# Altium schematic sheet BLE_NRF52832.SchDoc(BLE_NRF52832)
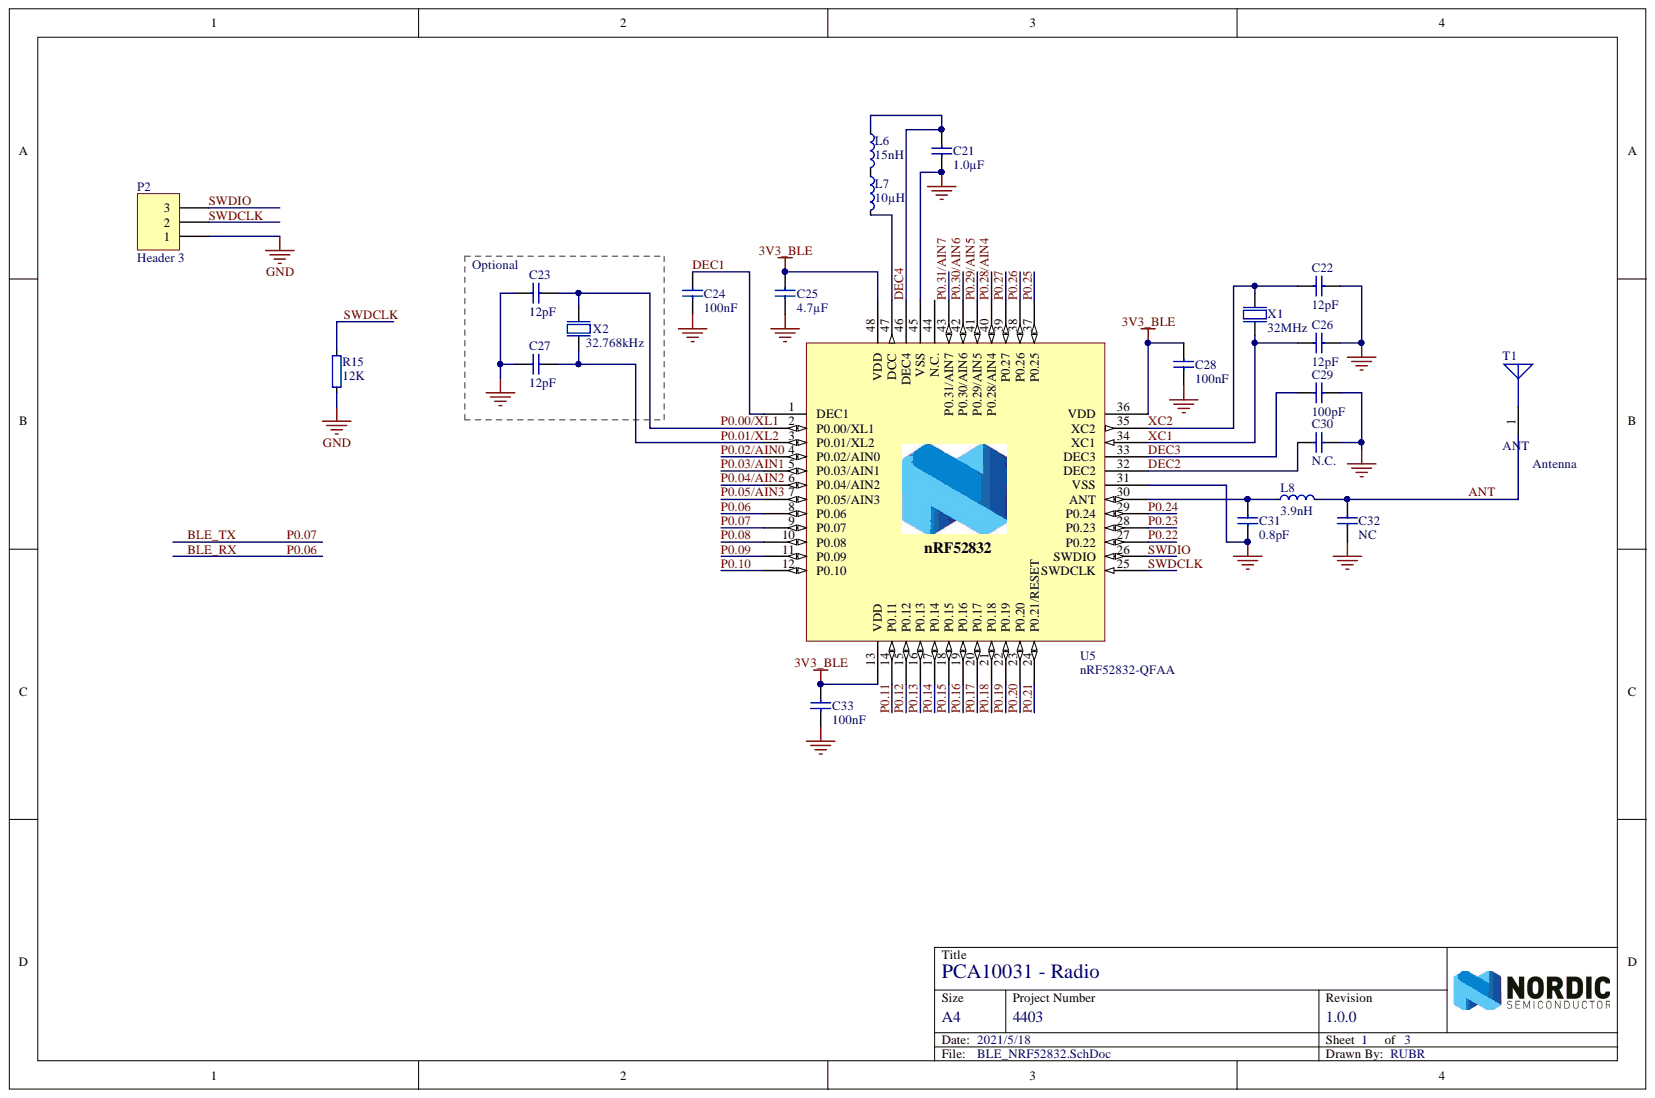
[diagram p0: BLE_NRF52832.SchDoc]

## Parts
C21  1.0µF  [0402C]
C22  12pF  [0402C]
C23  12pF  [0402C]
C24  100nF  [0402C]
C25  4.7µF  [C0603]
C26  12pF  [0402C]
C27  12pF  [0402C]
C28  100nF  [0402C]
C29  100pF  [0402C]
C30  N.C.  [0402C]
C31  0.8pF  [0402C]
C32  NC  [0402C]
C33  100nF  [0402C]
L6  15nH  [0402L]
L7  10µH  [0402L]
L8  3.9nH  [0402L]
P2  Header 3  [HDR1X3]
R15  12K  [0402R]
T1  ANT
U5  nRF52832-QFAA  [QFN40P600X600X90-48N]
X1  32MHz  [BT-XTAL_2016]
X2  32.768kHz  [X_2012]

## Nets
3V3_BLE: C25.1 C28.1 C33.1 U5.13(VDD) U5.36(VDD) U5.48(VDD)
GND: C21.1 C22.2 C23.2 C24.2 C25.2 C26.2 C27.2 C28.2 C29.2 C30.1 C31.1 C32.1 C33.2 P2.1 R15.1 U5.31(VSS) U5.45(VSS) U5.49 X1.2 X1.4
ANT: C32.2 L8.2 T1.1
BLE_RX: U5.8(P0.06)
BLE_TX: U5.9(P0.07)
DEC1: C24.1 U5.1(DEC1)
DEC2: C30.2 U5.32(DEC2)
DEC3: C29.1 U5.33(DEC3)
DEC4: C21.2 L6.1 U5.46(DEC4)
P0.00/XL1: C23.1 U5.2(P0.00/XL1) X2.1
P0.01/XL2: C27.1 U5.3(P0.01/XL2) X2.2
P0.02/AIN0: U5.4(P0.02/AIN0)
P0.03/AIN1: U5.5(P0.03/AIN1)
P0.04/AIN2: U5.6(P0.04/AIN2)
P0.05/AIN3: U5.7(P0.05/AIN3)
P0.08: U5.10(P0.08)
P0.09: U5.11(P0.09)
P0.10: U5.12(P0.10)
P0.11: U5.14(P0.11)
P0.12: U5.15(P0.12)
P0.13: U5.16(P0.13)
P0.14: U5.17(P0.14)
P0.15: U5.18(P0.15)
P0.16: U5.19(P0.16)
P0.17: U5.20(P0.17)
P0.18: U5.21(P0.18)
P0.19: U5.22(P0.19)
P0.20: U5.23(P0.20)
P0.21: U5.24(P0.21/RESET)
P0.22: U5.27(P0.22)
P0.23: U5.28(P0.23)
P0.24: U5.29(P0.24)
P0.25: U5.37(P0.25)
P0.26: U5.38(P0.26)
P0.27: U5.39(P0.27)
P0.28/AIN4: U5.40(P0.28/AIN4)
P0.29/AIN5: U5.41(P0.29/AIN5)
P0.30/AIN6: U5.42(P0.30/AIN6)
P0.31/AIN7: U5.43(P0.31/AIN7)
SWDCLK: P2.2 R15.2 U5.25(SWDCLK)
SWDIO: P2.3 U5.26(SWDIO)
XC1: C26.1 U5.34(XC1) X1.1
XC2: C22.1 U5.35(XC2) X1.3
NetC31_2: C31.2 L8.1 U5.30(ANT)
NetL6_2: L6.2 L7.1
NetL7_2: L7.2 U5.47(DCC)

Not connected: U5 44(N.C.)

## Library data of the parts
C21 = C0603-1µ0: Capacitor, X7R, ±10%; Artikkelnr Noca: 20039335; Artikkelnr Simpro: N.A.; Database Table Name: Capacitors$; Note: 16V; Component Kind: Standard; Footprint Doc: 0603; LatestRevisionDate: 2013-06-05; Library Name: Nordic Passive DB.DbLib; Part Number: C0603-1µ0; Pin Count: 2; Published: 2009-05-08; Value: 1.0µF; Publisher: Nordic Semiconductor
C22 C23 C26 C27 = C0402-12p: Capacitor, NP0, ±2%; Artikkelnr Noca: 20029159; Artikkelnr Simpro: N.A.; Database Table Name: Capacitors$; Note: 50V; Component Kind: Standard; Footprint Doc: 0402; LatestRevisionDate: 2011-05-11; Library Name: Nordic Passive DB.DbLib; Part Number: C0402-12p; Pin Count: 2; Published: 2009-05-08; Value: 12pF; Publisher: Nordic Semiconductor
C24 C28 C33 = C0402-100n: Capacitor, X7R, ±10%; Artikkelnr Noca: 20039200; Artikkelnr Simpro: N.A.; Database Table Name: Capacitors$; Note: 16V; Component Kind: Standard; Footprint Doc: 0402; LatestRevisionDate: 2009-11-23; Library Name: Nordic Passive DB.DbLib; Part Number: C0402-100n; Pin Count: 2; Published: 2009-05-08; Value: 100nF; Publisher: Nordic Semiconductor
C25 = C0603-4µ7: Capacitor, X5R, ±10%; Artikkelnr Noca: 20069060; Artikkelnr Simpro: N.A.; Database Table Name: Capacitors$; Note: 6.3V; Component Kind: Standard; Footprint Doc: 0603; LatestRevisionDate: 2009-11-23; Library Name: Nordic Passive DB.DbLib; Part Number: C0603-4µ7; Pin Count: 2; Published: 2009-05-08; Value: 4.7µF; Publisher: Nordic Semiconductor
C29 = C0402-100p: Capacitor, NP0, ±5%; Artikkelnr Noca: 20029135; Artikkelnr Simpro: N.A.; Database Table Name: Capacitors$; Note: 50V; Component Kind: Standard; Footprint Doc: 0402; LatestRevisionDate: 2009-05-08; Library Name: Nordic Passive DB.DbLib; Part Number: C0402-100p; Pin Count: 2; Published: 2009-05-08; Value: 100pF; Publisher: Nordic Semiconductor
C30 = C0402-N.C.: Not mounted; Artikkelnr Noca: N.A.; Database Table Name: Capacitors$; Note: Chip Cap; Artikkelnr Simpro: N.A.; Component Kind: Standard; Footprint Doc: 0402; LatestRevisionDate: 2009-05-08; Library Name: Nordic Passive DB.DbLib; Part Number: C0402-N.C.; Pin Count: 2; Published: 2009-05-08; Value: N.C.; Publisher: Nordic Semiconductor
C31 = C0402-0.8p: Capacitor, NP0, ±5%; Artikkelnr Noca: 20029147; Artikkelnr Simpro: N.A.; Database Table Name: Capacitors$; Note: 50V; Component Kind: Standard; Footprint Doc: 0402; LatestRevisionDate: 2012-11-21; Library Name: Nordic Passive DB.DbLib; Part Number: C0402-0.8p; Pin Count: 2; Published: 2009-05-08; Value: 0.8pF; Publisher: Nordic Semiconductor
C32 = C0402-0.8p: Capacitor, NP0, ±5%; Artikkelnr Noca: 20029147; Artikkelnr Simpro: N.A.; Database Table Name: Capacitors$; Note: 50V; Value: 0.8pF; Component Kind: Standard; Footprint Doc: 0402; LatestRevisionDate: 2012-11-21; Library Name: Nordic Passive DB.DbLib; Part Number: C0402-0.8p; Pin Count: 2; Published: 2009-05-08; Publisher: Nordic Semiconductor
L6 = L0402-15n: High frequency chip inductor ±10%; 2nd source part number: 658-1328; Manufacturer: Taiyo Yuden; Manufacturer Part Number: HK100515NJ-T; Artikkelnr Noca: 33029323; Artikkelnr Simpro: N.A.; Database Table Name: Inductors$; 2nd source Supplier: Farnell; Component Kind: Standard; Datasheet: http://www.yuden.co.jp/productdata/catalog/en/mlci04_e.pdf; Footprint Doc: 0402; LatestRevisionDate: 2012-09-19; Library Name: Nordic Passive DB.DbLib; Note: High frequency chip inductor ±10%; Part Number: L0402-15n; Pin Count: 2; Published: 2010-07-12; Supplier: Digi-Key; Supplier part number: 587-1521-1-ND; Value: 15nH; Publisher: Nordic Semiconductor
L7 = L0603-10µ: Chip inductor, IDC,min = 50 mA, ±20%; 2nd source part number: 178-6105; Manufacturer: Taiyo Yuden; Manufacturer Part Number: LBMF1608T100K; Artikkelnr Noca: 33029314; Artikkelnr Simpro: N.A.; Database Table Name: Inductors$; Note: LBMF1608T100K 10µH 80mA 0603; 2nd source Supplier: Farnell; Component Kind: Standard; Datasheet: http://www.yuden.co.jp/ut/product/pdf/elb2_e.pdf; Footprint Doc: 0603; LatestRevisionDate: 2012-09-19; Library Name: Nordic Passive DB.DbLib; Part Number: L0603-10µ; Pin Count: 2; Published: 2010-02-24; Supplier: Digi-Key; Supplier part number: 587-1714-1-ND; Value: 10µH; Publisher: Nordic Semiconductor
L8 = L0402-3n9: High frequency chip inductor ±5%; Manufacturer: Murata; Manufacturer Part Number: LQP15MN3N9B02D; Artikkelnr Noca: 33029151; Artikkelnr Simpro: N.A.; Database Table Name: Inductors$; Component Kind: Standard; Datasheet: http://search.murata.co.jp/Ceramy/image/img/PDF/ENG/L0075S0084LQP15M.pdf; Footprint Doc: 0402; LatestRevisionDate: 2012-09-19; Library Name: Nordic Passive DB.DbLib; Note: High frequency chip inductor ±5%; Part Number: L0402-3n9; Pin Count: 2; Published: 2009-05-08; Supplier: Digi-Key; Supplier part number: 490-1131-1-ND; Value: 3.9nH; Publisher: Nordic Semiconductor
P2 = Header 3: Header, 3-Pin; Component Kind: Standard; LatestRevisionDate: 17-Jul-2002; LatestRevisionNote: Re-released for DXP Platform.; Library Name: Miscellaneous Connectors.IntLib; Signal Integrity: Connector; Publisher: Altium Limited
R15 = R0402-18k: Resistor, ±1%, 0.063W; Artikkelnr Noca: 27049103; Artikkelnr Simpro: N.A.; Database Table Name: Resistors$; Note: Chip Res 1%; Component Kind: Standard; Footprint Doc: 0402; LatestRevisionDate: 2009-11-23; Library Name: Nordic Passive DB.DbLib; Part Number: R0402-18k; Pin Count: 2; Published: 2009-05-08; Supplier: Farnell; Supplier part number: 173-8867; Value: 12K; Publisher: Nordic Semiconductor
U5 = nRF52832-QFAA: Multi-protocol Bluetooth Low Energy and 2.4GHz proprietary system-on-chip; Manufacturer: Nordic Semiconductor; Artikkelnr Noca: N.A.; Database Table Name: nRF Devices$; Artikkelnr Simpro: N.A.; Component Kind: Standard; Footprint Doc: QFN-48; LatestRevisionDate: 2014-11-26; Library Name: Nordic Active DB.DbLib; Manufacturer Part Number: nRF52832-QFAA; Part Number: nRF52832-QFAA; Pin Count: 48; Published: 2014-11-26; Supplier: Nordic Semiconductor; Value: nRF52832-QFAA; Publisher: Nordic Semiconductor
X1 = XTAL_SMD2016_32MHz_8pF_Epson: XTAL SMD 2016, 32MHz, Cl=8pF, Total Tol: ±40ppm; Manufacturer: Epson Toyocom; Manufacturer Part Number: Q22FA12800025; Artikkelnr Noca: N.A.; Database Table Name: Crystal_Osc$; Note: XTAL SMD 32.000MHz; Artikkelnr Simpro: N.A.; Component Kind: Standard; Datasheet: http://www.epsondevice.com/docs/qd/en/DownloadServlet?id=ID000449; Footprint Doc: XTAL_2016; LatestRevisionDate: 2014-11-27; Library Name: Nordic Discrete DB.DbLib; Part Number: XTAL_SMD2016_32MHz_8pF_Epson; Pin Count: 4; Published: 2012-03-09; Supplier: Farnell; Supplier part number: 171-2806; Value: 32MHz; Publisher: Nordic Semiconductor
X2 = XTAL_FC-135 32.768k: XTAL SMD 3215, 32.768kHz, 9pF, ±20ppm; 2nd source part number: 127-8037; Manufacturer: Epson Toyocom; Manufacturer Part Number: FC-135 32.768KHZ ±20PPM,9.0PF; Artikkelnr Noca: 22019091; Artikkelnr Simpro: N.A.; Database Table Name: Crystal_Osc$; Note: XTAL SMD 32.768kHz, 3215; 2nd source Supplier: Farnell; Component Kind: Standard; Datasheet: http://www.farnell.com/datasheets/57480.pdf; Footprint Doc: XTAL_3215; LatestRevisionDate: 2014-03-27; Library Name: Nordic Discrete DB.DbLib; Part Number: XTAL_FC-135 32.768k; Pin Count: 2; Published: 2010-10-18; Supplier: Digi-Key; Supplier part number: FC-135 32.7680KA-AC-ND; Value: 32.768kHz; Publisher: Nordic Semiconductor
T1 = ANT: Component Kind: Standard; Library Name: 芯片类.SchLib
